# Altium schematic sheet 03 - BCM4343W_Module.SchDoc("03 - BCM4343W_Module")
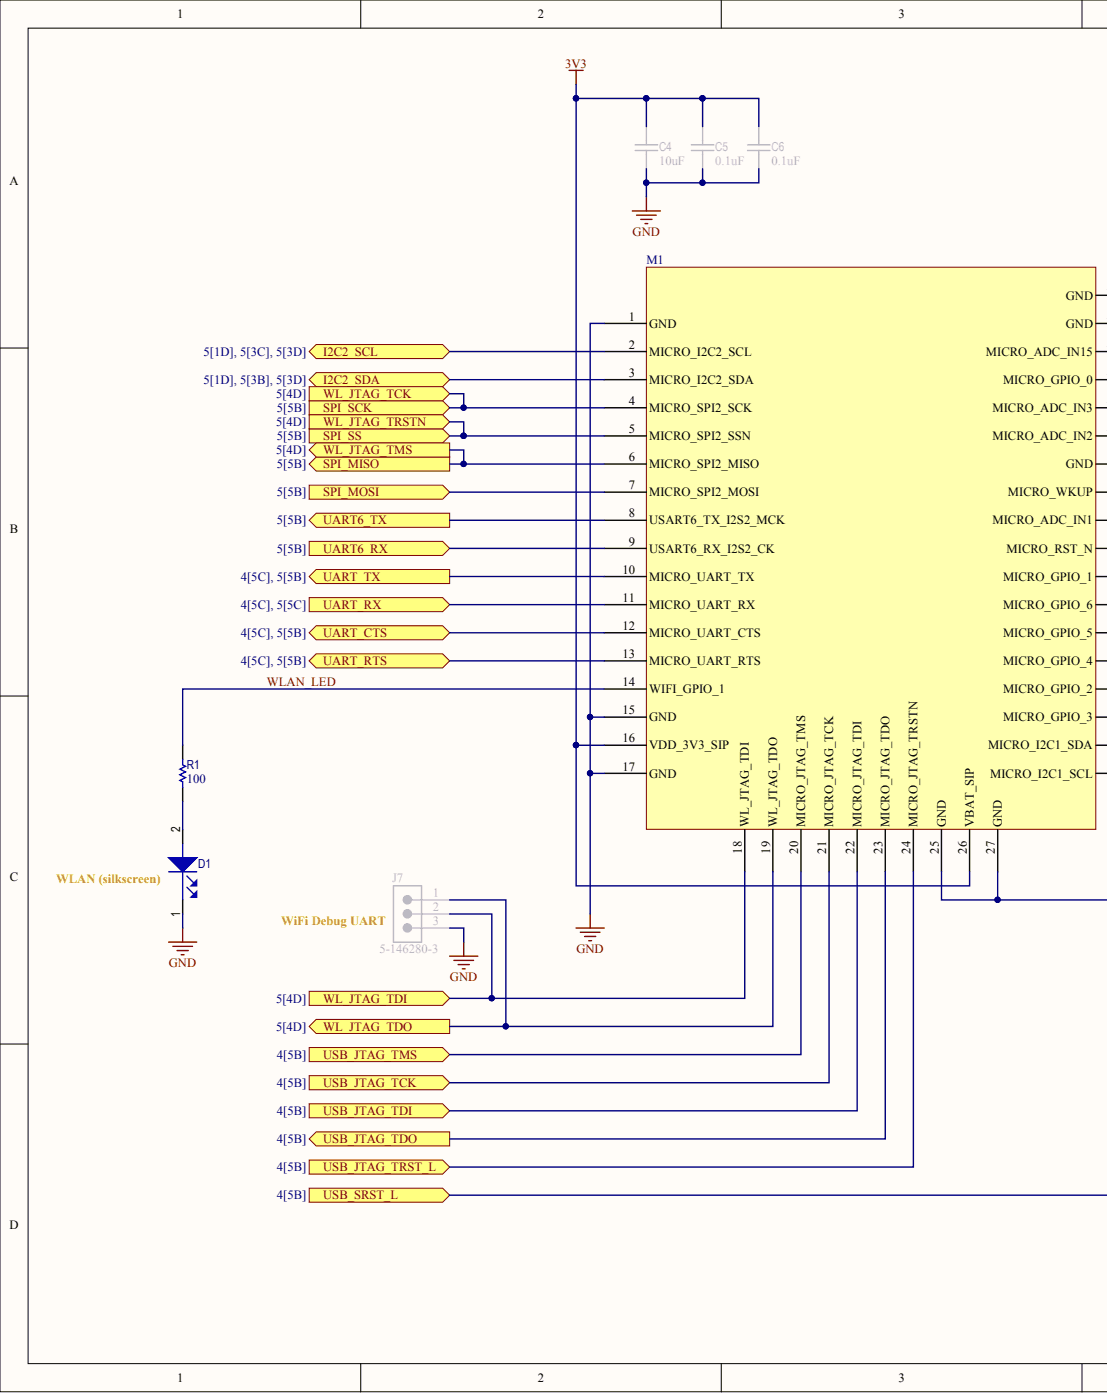
[diagram p0: 03 - BCM4343W_Module.SchDoc, left]
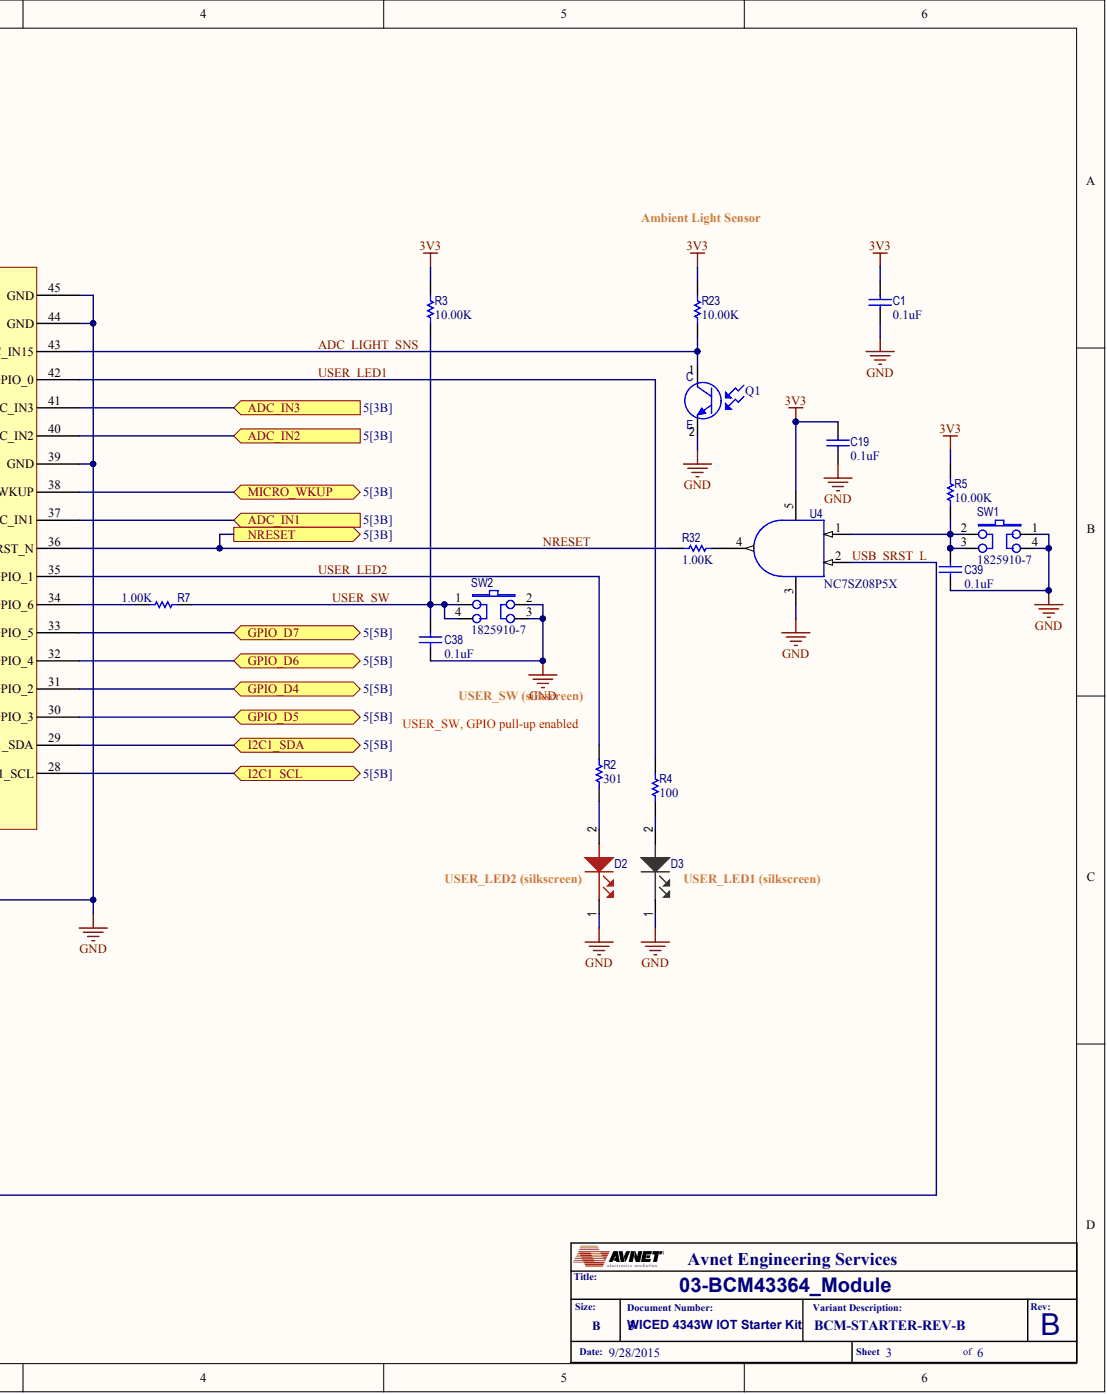
[diagram p1: 03 - BCM4343W_Module.SchDoc, right]

## Parts
C1  0.1uF  [CAPC0402(1005)70_N]
C4  10uF  [CAPC0402(1005)70_N]
C5  0.1uF  [CAPC0402(1005)70_N]
C6  0.1uF  [CAPC0402(1005)70_N]
C19  0.1uF  [CAPC0402(1005)70_N]
C38  0.1uF  [CAPC0402(1005)70_N]
C39  0.1uF  [CAPC0402(1005)70_N]
D1  LED CHIPLED BLUE 470NM 0603 SMD  [D0603 (1608 Metric), BLUE]  (value not drawn)
D2  LED CHIPLED 633NM RED 0603 SMD  [D0603 (1608 Metric), RED]  (value not drawn)
D3  LED SMARTLED 570NM GREEN 0603  [D0603 (1608 Metric), GREEN]  (value not drawn)
J7  5-146280-3  [HDR-U-TH-VERT-3S-0.100 (2.54mm)]
M1  SSB-WBM-N08 Promo Module v1.0  [SSB-WBM-N08]  (value not drawn)
Q1  LIGHT SENSOR AMBIENT SMD  [EVERLIGHT_ALS-PT19-315C/L177/TR8]  (value not drawn)
R1  100  [RESC0402(1005)_N]
R2  301  [RESC0402(1005)_N]
R3  10.00K  [RESC0402(1005)_N]
R4  100  [RESC0402(1005)_N]
R5  10.00K  [RESC0402(1005)_N]
R7  1.00K  [RESC0402(1005)_N]
R23  10.00K  [RESC0402(1005)_N]
R32  1.00K  [RESC0402(1005)_N]
SW1  MFGPN 1: 1825910-7  [TE_1825910-7]  (hidden comment: SWITCH TACTILE SPST-NO 0.05A 24V)
SW2  MFGPN 1: 1825910-7  [TE_1825910-7]  (hidden comment: SWITCH TACTILE SPST-NO 0.05A 24V)
U4  MFGPN 1: NC7SZ08P5X  [SOT353 (SC70-5)]  (hidden comment: AND SM LVCMOS Single)

## Ports
ADC_IN1, ADC_IN2, ADC_IN3, GPIO_D4, GPIO_D5, GPIO_D6, GPIO_D7, I2C1_SCL, I2C1_SDA, I2C2_SCL, I2C2_SDA, MICRO_WKUP, NRESET, SPI_MISO, SPI_MOSI, SPI_SCK, SPI_SS, UART6_RX, UART6_TX, UART_CTS, UART_RTS, UART_RX, UART_TX, USB_JTAG_TCK, USB_JTAG_TDI, USB_JTAG_TDO, USB_JTAG_TMS, USB_JTAG_TRST_L, USB_SRST_L, WL_JTAG_TCK, WL_JTAG_TDI, WL_JTAG_TDO, WL_JTAG_TMS, WL_JTAG_TRSTN

## Nets
3V3: C1.2 C4.2 C5.2 C6.2 C19.2 M1.16(VDD_3V3_SIP) M1.26(VBAT_SIP) R3.2 R5.2 R23.2 U4.5
GND: C1.1 C4.1 C5.1 C6.1 C19.1 C38.1 C39.1 D1.1 D2.1 D3.1 J7.3 M1.1(GND) M1.15(GND) M1.17(GND) M1.25(GND) M1.27(GND) M1.39(GND) M1.44(GND) M1.45(GND) Q1.2 SW1.1 SW1.4 SW2.2 SW2.3 U4.3
ADC_IN1: M1.37(MICRO_ADC_IN1) ; port ADC_IN1
ADC_IN2: M1.40(MICRO_ADC_IN2) ; port ADC_IN2
ADC_IN3: M1.41(MICRO_ADC_IN3) ; port ADC_IN3
ADC_LIGHT_SNS: M1.43(MICRO_ADC_IN15) Q1.1 R23.1
GPIO_D4: M1.31(MICRO_GPIO_2) ; port GPIO_D4
GPIO_D5: M1.30(MICRO_GPIO_3) ; port GPIO_D5
GPIO_D6: M1.32(MICRO_GPIO_4) ; port GPIO_D6
GPIO_D7: M1.33(MICRO_GPIO_5) ; port GPIO_D7
I2C1_SCL: M1.28(MICRO_I2C1_SCL) ; port I2C1_SCL
I2C1_SDA: M1.29(MICRO_I2C1_SDA) ; port I2C1_SDA
I2C2_SCL: M1.2(MICRO_I2C2_SCL) ; port I2C2_SCL
I2C2_SDA: M1.3(MICRO_I2C2_SDA) ; port I2C2_SDA
MICRO_WKUP: M1.38(MICRO_WKUP) ; port MICRO_WKUP
NRESET: M1.36(MICRO_RST_N) R32.1 ; port NRESET
SPI_MISO: M1.6(MICRO_SPI2_MISO) ; port SPI_MISO, port WL_JTAG_TMS
SPI_MOSI: M1.7(MICRO_SPI2_MOSI) ; port SPI_MOSI
SPI_SCK: M1.4(MICRO_SPI2_SCK) ; port SPI_SCK, port WL_JTAG_TCK
SPI_SS: M1.5(MICRO_SPI2_SSN) ; port SPI_SS, port WL_JTAG_TRSTN
UART6_RX: M1.9(USART6_RX_I2S2_CK) ; port UART6_RX
UART6_TX: M1.8(USART6_TX_I2S2_MCK) ; port UART6_TX
UART_CTS: M1.12(MICRO_UART_CTS) ; port UART_CTS
UART_RTS: M1.13(MICRO_UART_RTS) ; port UART_RTS
UART_RX: M1.11(MICRO_UART_RX) ; port UART_RX
UART_TX: M1.10(MICRO_UART_TX) ; port UART_TX
USB_JTAG_TCK: M1.21(MICRO_JTAG_TCK) ; port USB_JTAG_TCK
USB_JTAG_TDI: M1.22(MICRO_JTAG_TDI) ; port USB_JTAG_TDI
USB_JTAG_TDO: M1.23(MICRO_JTAG_TDO) ; port USB_JTAG_TDO
USB_JTAG_TMS: M1.20(MICRO_JTAG_TMS) ; port USB_JTAG_TMS
USB_JTAG_TRST_L: M1.24(MICRO_JTAG_TRSTN) ; port USB_JTAG_TRST_L
USB_SRST_L: U4.2 ; port USB_SRST_L
USER_LED1: M1.42(MICRO_GPIO_0) R4.1
USER_LED2: M1.35(MICRO_GPIO_1) R2.2
USER_SW: C38.2 R3.1 R7.1 SW2.1 SW2.4
WL_JTAG_TDI: J7.2 M1.18(WL_JTAG_TDI) ; port WL_JTAG_TDI
WL_JTAG_TDO: J7.1 M1.19(WL_JTAG_TDO) ; port WL_JTAG_TDO
WLAN_LED: M1.14(WIFI_GPIO_1) R1.2
NetC39_2: C39.2 R5.1 SW1.2 SW1.3 U4.1
NetD1_2: D1.2 R1.1
NetD2_2: D2.2 R2.1
NetD3_2: D3.2 R4.2
NetM1_34: M1.34(MICRO_GPIO_6) R7.2
NetR32_2: R32.2 U4.4

## Library data of the parts
C1 C5 C6 C19 C38 C39 = AVPC-0000034,A-0: CAP CER 0.1UF 25V 10% X7R 0402; Material: CERAMIC; MFG 1: AVX; MFGPN 1: 04023C104KAT2A; Mount Type (SM/TH): SM; Numeric Value (R=Ω, C=uF, L=uH, FB=Ω ): 0.1; Polarized (Y/N): N; Preferrence Code: A; Temp-Range: -55 to 125C; AES PN: AVPC-0000034; Component Kind: Standard; Component Type: CAP; Dielectric Type: X7R; MFG 1 Datasheet link: http://www.avx.com/docs/Catalogs/cx7r.pdf; Package: 0402 (1005 Metric); Rated Voltage: 25V; RoHS: Y; Tolerance: 10%; Value: 0.1uF
  C1 C5 C6: Library Name: BD-BCM-STARTER.SchLib
  C19 C38 C39: Library Name: AES Altium Vault
C4 = AVPC-0000035,A-0: CAP CER 10UF 10V 20% X5R 0402; Material: CERAMIC; MFG 1: SAMSUNG; MFGPN 1: CL05A106MP5NUNC; Mount Type (SM/TH): SM; Numeric Value (R=Ω, C=uF, L=uH, FB=Ω ): 10; Polarized (Y/N): N; Preferrence Code: A; Temp-Range: -55 to 85C; AES PN: AVPC-0000035; Component Kind: Standard; Component Type: CAP; Dielectric Type: X5R; MFG 1 Datasheet link: http://www.samsungsem.com/global/support/library/product-catalog/__icsFiles/afieldfile/2014/11/13/MLCC.pdf; Package: 0402 (1005 Metric); Rated Voltage: 10V; RoHS: Y; Tolerance: 20%; Value: 10uF; Library Name: AES Altium Vault
D1 = AVDL-0000012,A-0: Forward Voltage: 2.85V; Lense Type: Diffused; MFG 1: OSRAM; MFGPN 1: LB Q39G-L2N2-35-1; Millicandela Rating: 29.5mcd; Mount Type (SM/TH): SM; Preferrence Code: A; Temp-Range: -40 to 85C; Wave-PK: 472nm; AES PN: AVDL-0000012; Color: BLUE; Component Kind: Standard; Component Type: LED; Description: LED CHIPLED BLUE 470NM 0603 SMD; MFG 1 Datasheet link: http://media.digikey.com/pdf/Data%20Sheets/Osram%20PDFs/LB_LT%20Q39G.pdf; Package: D0603 (1608 Metric); RoHS: Y; Wavelength: 470nm; Library Name: AES Altium Vault
D2 = AVDL-0000011,A-0: Forward Voltage: 2.0V; Lense Type: Diffused; MFG 1: OSRAM; MFGPN 1: LS Q976-NR-1; Millicandela Rating: 104mcd; Mount Type (SM/TH): SM; Preferrence Code: A; Temp-Range: -40 to 85C; Wave-PK: 645nm; AES PN: AVDL-0000011; Color: RED; Component Kind: Standard; Component Type: LED; Description: LED CHIPLED 633NM RED 0603 SMD; MFG 1 Datasheet link: http://www.osram-os.com/Graphics/XPic9/00128792_0.pdf; Package: D0603 (1608 Metric); RoHS: Y; Wavelength: 633nm; Library Name: AES Altium Vault
D3 = AVDL-0000010,A-0: Forward Voltage: 1.7V; Lense Type: Diffused; MFG 1: OSRAM; MFGPN 1: LG L29K-F2J1-24-Z; Millicandela Rating: 3.5mcd; Mount Type (SM/TH): SM; Preferrence Code: A; Temp-Range: -40 to 85C; Wave-PK: 572nm; AES PN: AVDL-0000010; Color: GREEN; Component Kind: Standard; Component Type: LED; Description: LED SMARTLED 570NM GREEN 0603; MFG 1 Datasheet link: http://www.osram-os.com/Graphics/XPic2/00077107_0.pdf; Package: D0603 (1608 Metric); RoHS: Y; Wavelength: 570nm; Library Name: AES Altium Vault
J7 = AVEC-0000014,A-2: CONN HEADR BRKWAY .100 3POS STR, SINGLE ROW; Header (M/F): M; MFG 1: TE; Mount Type (SM/TH): TH; Number of Positions: 3; Pin Pitch: 0.100(2.54mm); Preferrence Code: A; Rated Current: 3.0A (Per Pin); Rated Voltage: DWV=750V; Schematic Symbol: 3-Pin, Male, Single Row; Shrouded (Y/N): N; Temp-Range: -65 to 105C; AES PN: AVEC-0000014; Color: BLACK; Component Kind: Standard; Component Type: CONNECTOR; Contact Mating Length: 0.230" (5.84mm); Contact Type: Gold, 15µin (0.38µm); Fastening Type: -; Features: -; Material: HEADER; MFG 1 Datasheet link: http://www.te.com/commerce/DocumentDelivery/DDEController?Action=srchrtrv&DocNm=146280&DocType=Customer+Drawing&DocLang=English; MFGPN 1: 5-146280-3; Number of Pins: 3; Number of Rows: 1; Orientation: Vertical; Package: HDR-U-TH-VERT-3S-0.100 (2.54mm); RoHS: Y; Row Pitch: -; Library Name: AES Altium Vault
M1 = AVAP-0000003,A-2: MFG 1: BROADCOM; Mount Type (SM/TH): SM; AES PN: AVAP-0000003; Component Kind: Standard; Component Type: RF Transceivers; Description: SSB-WBM-N08 Promo Module v1.0; Material: PCBA; MFGPN 1: SSB-WBM-N08; Package: -; Preferrence Code: -; RoHS: Y; Temp-Range: -; Library Name: AES Altium Vault
Q1 = AVDT-0000008,A-1: Material: PHOTO; MFG 1: EVERLIGHT; MFGPN 1: ALS-PT19-315C/L177/TR8; Mount Type (SM/TH): SM; Preferrence Code: A; Temp-Range: -40 to 85C; Wavelength: 630nm; AES PN: AVDT-0000008; Component Kind: Standard; Component Type: Transistor; Description: LIGHT SENSOR AMBIENT SMD; MFG 1 Datasheet link: http://www.everlight.com/file/ProductFile/201407061531031645.pdf; Package: 0703 (1708); RoHS: Y; Library Name: AES Altium Vault
R1 R4 = AVPR-0000783,A-2: RES 100 OHM 0402 1% 1/16W; MFG 1: TBD; Mount Type (SM/TH): SM; Preferrence Code: A; Rated Power: 0.063W; Temp-Range: -55 to 125C; AES PN: AVPR-0000783; Component Kind: Standard; Component Type: RESISTOR; Material: Thick Film; MFG 2: TBD; MFG 3: TBD; MFGPN 1: TBD; MFGPN 2: TBD; MFGPN 3: TBD; Numeric Value (R=Ω, C=uF, L=uH, FB=Ω ): 100; Package: 0402 (1005 Metric); RoHS: Y; Tolerance: 1%; Value: 100; Library Name: AES Altium Vault
R2 = AVPR-0000830,A-2: RES 301 OHM 0402 1% 1/16W; MFG 1: TBD; Mount Type (SM/TH): SM; Preferrence Code: A; Rated Power: 0.063W; Temp-Range: -55 to 125C; AES PN: AVPR-0000830; Component Kind: Standard; Component Type: RESISTOR; Material: Thick Film; MFG 2: TBD; MFG 3: TBD; MFGPN 1: TBD; MFGPN 2: TBD; MFGPN 3: TBD; Numeric Value (R=Ω, C=uF, L=uH, FB=Ω ): 301; Package: 0402 (1005 Metric); RoHS: Y; Tolerance: 1%; Value: 301; Library Name: AES Altium Vault
R3 R5 R23 = AVPR-0000977,A-2: RES 10.0K OHM 0402 1% 1/16W; MFG 1: TBD; Mount Type (SM/TH): SM; Numeric Value (R=Ω, C=uF, L=uH, FB=Ω ): 10000; Preferrence Code: A; Rated Power: 0.063W; Temp-Range: -55 to 125C; AES PN: AVPR-0000977; Component Kind: Standard; Component Type: RESISTOR; Material: Thick Film; MFG 2: TBD; MFG 3: TBD; MFGPN 1: TBD; MFGPN 2: TBD; MFGPN 3: TBD; Package: 0402 (1005 Metric); RoHS: Y; Tolerance: 1%; Value: 10.00K; Library Name: BD-BCM-STARTER.SchLib
R7 R32 = AVPR-0000880,A-2: RES 1.00K OHM 0402 1% 1/16W; MFG 1: TBD; Mount Type (SM/TH): SM; Numeric Value (R=Ω, C=uF, L=uH, FB=Ω ): 1000; Preferrence Code: A; Rated Power: 0.063W; Temp-Range: -55 to 125C; AES PN: AVPR-0000880; Component Kind: Standard; Component Type: RESISTOR; Material: Thick Film; MFG 2: TBD; MFG 3: TBD; MFGPN 1: TBD; MFGPN 2: TBD; MFGPN 3: TBD; Package: 0402 (1005 Metric); RoHS: Y; Tolerance: 1%; Value: 1.00K; Library Name: AES Altium Vault
SW1 SW2 = AVES-0000008,A-1: MFG 1: TE; Mount Type (SM/TH): TH; Preferrence Code: A; Rated Current: 50mA; Switch Type: Pushbutton; Temp-Range: -30 to 85C; AES PN: AVES-0000008; Component Kind: Standard; Component Type: SWITCH; Contact Type: OFF-MOM; Description: SWITCH TACTILE SPST-NO 0.05A 24V; Material: SPST-NO; MFG 1 Datasheet link: http://www.te.com/commerce/DocumentDelivery/DDEController?Action=srchrtrv&DocNm=1825910&DocType=Customer+Drawing&DocLang=English; Number of Positions: -; Package: TE_1825910-7; Rated Voltage: 24V; RoHS: Y; Library Name: AES Altium Vault
U4 = AVID-0000025,A-0: Logic High: 0.7-0.75Vcc; Logic Low: 0.25-0.3Vcc; Logic Type: AND Gate; MFG 1: FAIRCHILD; Number of Channels: 1; Number of Inputs: 2; Preferrence Code: A; Propagation Delay: 4.5ns @ 5V, 50pF; Supply Voltage: 1.65 to 5.5V; Temp-Range: -40 to 85C; AES PN: AVID-0000025; Component Kind: Standard; Component Type: IC; Data Rate: -; Description: AND SM LVCMOS Single; Frequency: -; Interface: -; Material: GULL; MFG 1 Datasheet link: http://www.fairchildsemi.com/datasheets/NC/NC7SZ08.pdf; Mount Type (SM/TH): SM; Package: SOT-353 (SC-70-5); RoHS: Y; Standards: -; Library Name: AES Altium Vault
